# Altium schematic sheet LCD_RGB&MIPI_DSI.SchDoc(LCD_RGB&MIPI_DSI)
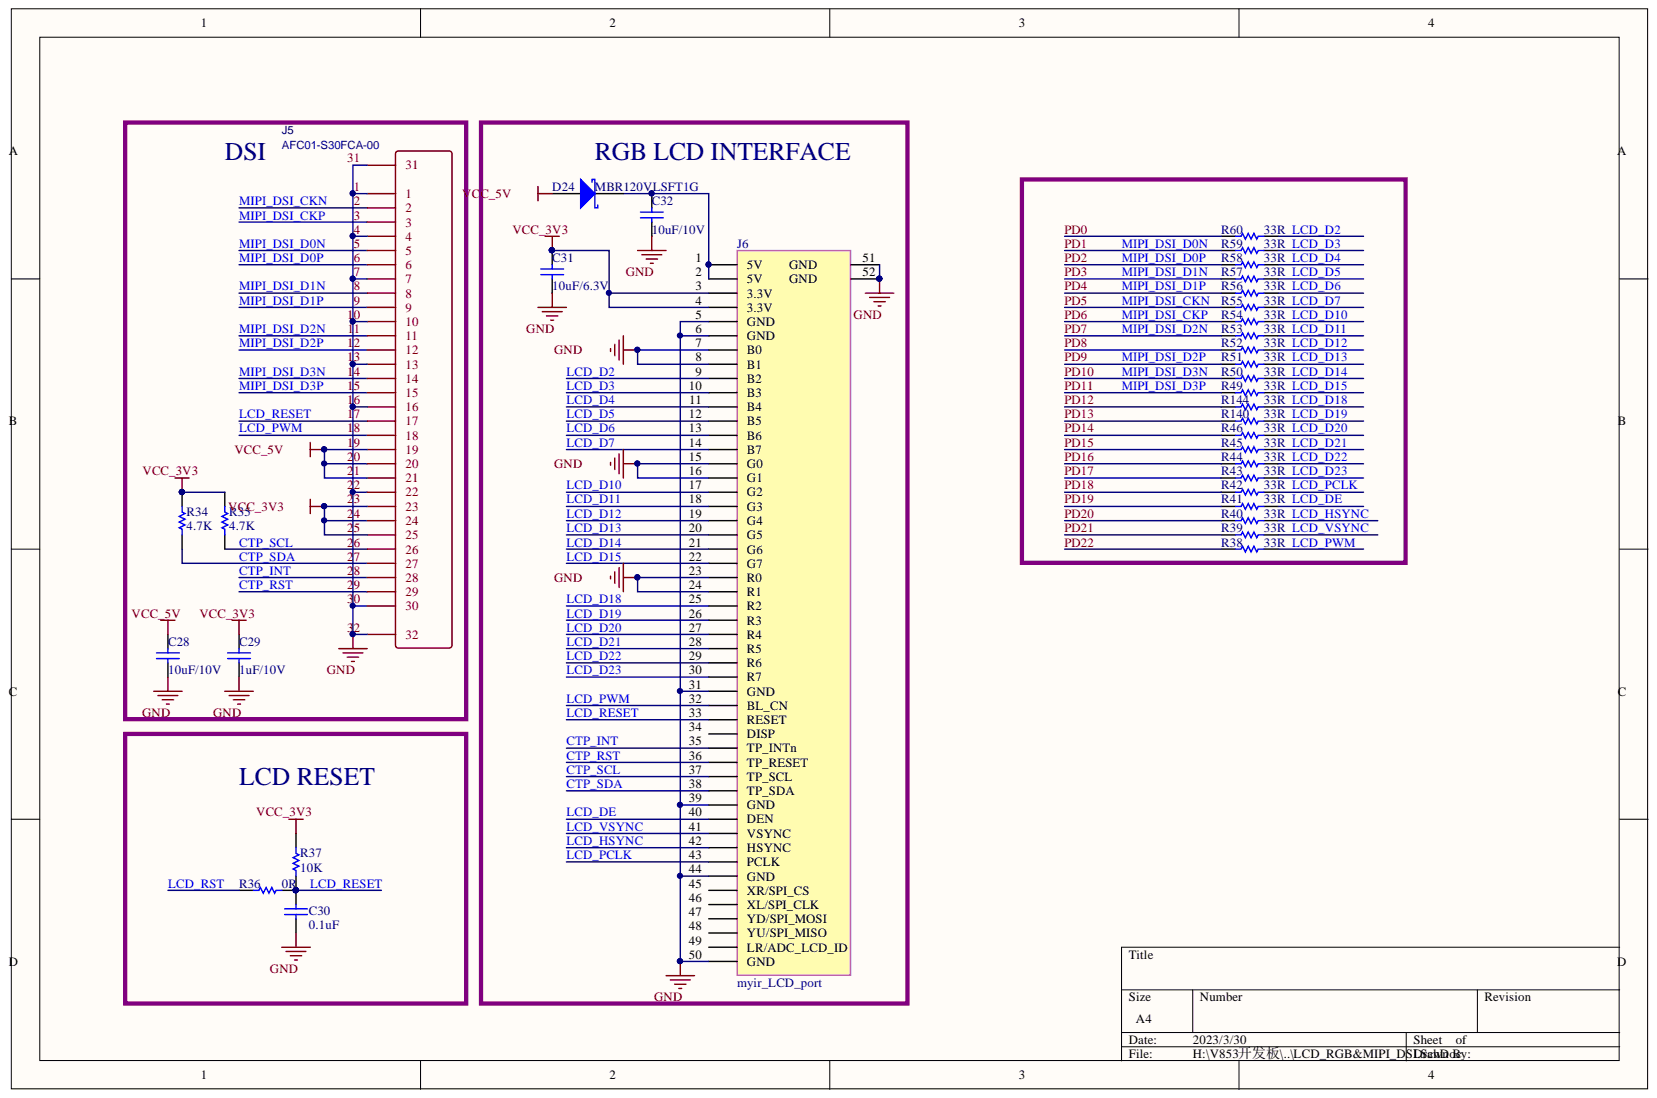
[diagram p0: LCD_RGB&MIPI_DSI.SchDoc]

## Parts
C28  10uF/10V  [0402]
C29  1uF/10V  [0402]
C30  0.1uF  [0402]
C31  10uF/6.3V  [0402]
C32  10uF/10V  [0402]
D24  MBR120VLSFT1G  [SOD-123_L2.8-W1.8-LS3.7-RD]
J5  AFC01-S30FCA-00  [FPC-SMD_AFC01-S30FCA-00]
J6  myir_LCD_port  [FPC50_0A5_SM_H]
R34  4.7K  [0402]
R35  4.7K  [0402]
R36  0R  [0402]
R37  10K  [0402]
R38  33R  [0402]
R39  33R  [0402]
R40  33R  [0402]
R41  33R  [0402]
R42  33R  [0402]
R43  33R  [0402]
R44  33R  [0402]
R45  33R  [0402]
R46  33R  [0402]
R49  33R  [0402]
R50  33R  [0402]
R51  33R  [0402]
R52  33R  [0402]
R53  33R  [0402]
R54  33R  [0402]
R55  33R  [0402]
R56  33R  [0402]
R57  33R  [0402]
R58  33R  [0402]
R59  33R  [0402]
R60  33R  [0402]
R140  33R  [0402]
R144  33R  [0402]

## Nets
GND: C28.1 C29.1 C30.2 C31.1 C32.1 J5.1 J5.4 J5.7 J5.10 J5.13 J5.16 J5.22 J5.30 J5.31 J5.32 J6.5(GND) J6.6(GND) J6.7(B0) J6.8(B1) J6.15(G0) J6.16(G1) J6.23(R0) J6.24(R1) J6.31(GND) J6.39(GND) J6.44(GND) J6.50(GND) J6.51 J6.52
VCC_3V3: C29.2 C31.2 J5.23 J5.24 J5.25 J6.3(3.3V) J6.4(3.3V) R34.2 R35.2 R37.2
VCC_5V: C28.2 D24.1 J5.19 J5.20 J5.21
CTP_INT: J5.28 J6.35(TP_INTn)
CTP_RST: J5.29 J6.36(TP_RESET)
CTP_SCL: J5.26 J6.37(TP_SCL) R35.1
CTP_SDA: J5.27 J6.38(TP_SDA) R34.1
LCD_D2: J6.9(B2) R60.1
LCD_D3: J6.10(B3) R59.1
LCD_D4: J6.11(B4) R58.1
LCD_D5: J6.12(B5) R57.1
LCD_D6: J6.13(B6) R56.1
LCD_D7: J6.14(B7) R55.1
LCD_D10: J6.17(G2) R54.1
LCD_D11: J6.18(G3) R53.1
LCD_D12: J6.19(G4) R52.1
LCD_D13: J6.20(G5) R51.1
LCD_D14: J6.21(G6) R50.1
LCD_D15: J6.22(G7) R49.1
LCD_D18: J6.25(R2) R144.1
LCD_D19: J6.26(R3) R140.1
LCD_D20: J6.27(R4) R46.1
LCD_D21: J6.28(R5) R45.1
LCD_D22: J6.29(R6) R44.1
LCD_D23: J6.30(R7) R43.1
LCD_DE: J6.40(DEN) R41.1
LCD_HSYNC: J6.42(HSYNC) R40.1
LCD_PCLK: J6.43(PCLK) R42.1
LCD_PWM: J5.18 J6.32(BL_CN) R38.1
LCD_RESET: C30.1 J5.17 J6.33(RESET) R36.1 R37.1
LCD_RST: R36.2
LCD_VSYNC: J6.41(VSYNC) R39.1
MIPI_DSI_CKN: J5.2 R55.2
MIPI_DSI_CKP: J5.3 R54.2
MIPI_DSI_D0N: J5.5 R59.2
MIPI_DSI_D0P: J5.6 R58.2
MIPI_DSI_D1N: J5.8 R57.2
MIPI_DSI_D1P: J5.9 R56.2
MIPI_DSI_D2N: J5.11 R53.2
MIPI_DSI_D2P: J5.12 R51.2
MIPI_DSI_D3N: J5.14 R50.2
MIPI_DSI_D3P: J5.15 R49.2
PD0: R60.2
PD8: R52.2
PD12: R144.2
PD13: R140.2
PD14: R46.2
PD15: R45.2
PD16: R44.2
PD17: R43.2
PD18: R42.2
PD19: R41.2
PD20: R40.2
PD21: R39.2
PD22: R38.2
NetC32_2: C32.2 D24.2 J6.1(5V) J6.2(5V)

Not connected: J6 34(DISP) 45(XR/SPI_CS) 46(XL/SPI_CLK) 47(YD/SPI_MOSI) 48(YU/SPI_MISO) 49(LR/ADC_LCD_ID)

## Library data of the parts
C28-C32 = Cap: Capacitor; PartNumber: CAP100NF; Component Kind: Standard; LatestRevisionDate: 17-Jul-2002; LatestRevisionNote: Re-released for DXP Platform.; Library Name: Miscellaneous Devices.IntLib; PackageReference: 0402; Published: 8-Jun-2000; Signal Integrity: Cap; Simulation: CAP; Publisher: Altium Limited
  C28 C32: Value: 10uF/10V
  C29: Value: 1uF/10V
  C30: Value: 0.1uF
  C31: Value: 10uF/6.3V
D24 = D Schottky: Schottky Diode; Code_JEDEC: DO-214-AA; Component Kind: Standard; LatestRevisionDate: 17-Jul-2002; LatestRevisionNote: Re-released for DXP Platform.; Library Name: Miscellaneous Devices.IntLib; PackageDescription: DO-214-AA/SMB; 2 C-Bend Leads; Body 5.3 x 3.6 mm, inc. leads (LxW); PackageReference: SMB; Published: 8-Jun-2000; Signal Integrity: D Schottky; Simulation: SKYDIODE; Value: MBR120VLSFT1G; Publisher: Altium Limited
J5 = AFC01-S30FCA-00: BOM_Manufacturer: JUSHUO; Contributor: LCSC; BOM_JLCPCB Part Class: Extended Part; BOM_Manufacturer Part: AFC01-S30FCA-00; BOM_Supplier: LCSC; BOM_Supplier Part: C262671; Component Kind: Standard; spicePre: F; spiceSymbolName: AFC01-S30FCA-00
R34-R46 R49-R60 R140 R144 = Res1: Resistor; PartNumber: RES2.2K; Component Kind: Standard; LatestRevisionDate: 17-Jul-2002; LatestRevisionNote: Re-released for DXP Platform.; Library Name: Miscellaneous Devices.IntLib; PackageReference: 0402; Published: 8-Jun-2000; Signal Integrity: Res; Simulation: RESISTOR; Publisher: Altium Limited
  R34 R35: Value: 4.7K
  R36: Value: 0R
  R37: Value: 10K
  R38-R46 R49-R60 R140 R144: Value: 33R
J6 = myir_LCD_port: Component Kind: Standard; Library Name: HM2.SchLib
